# Altium schematic sheet GSM_EC200U.SchDoc(GSM_EC200U)
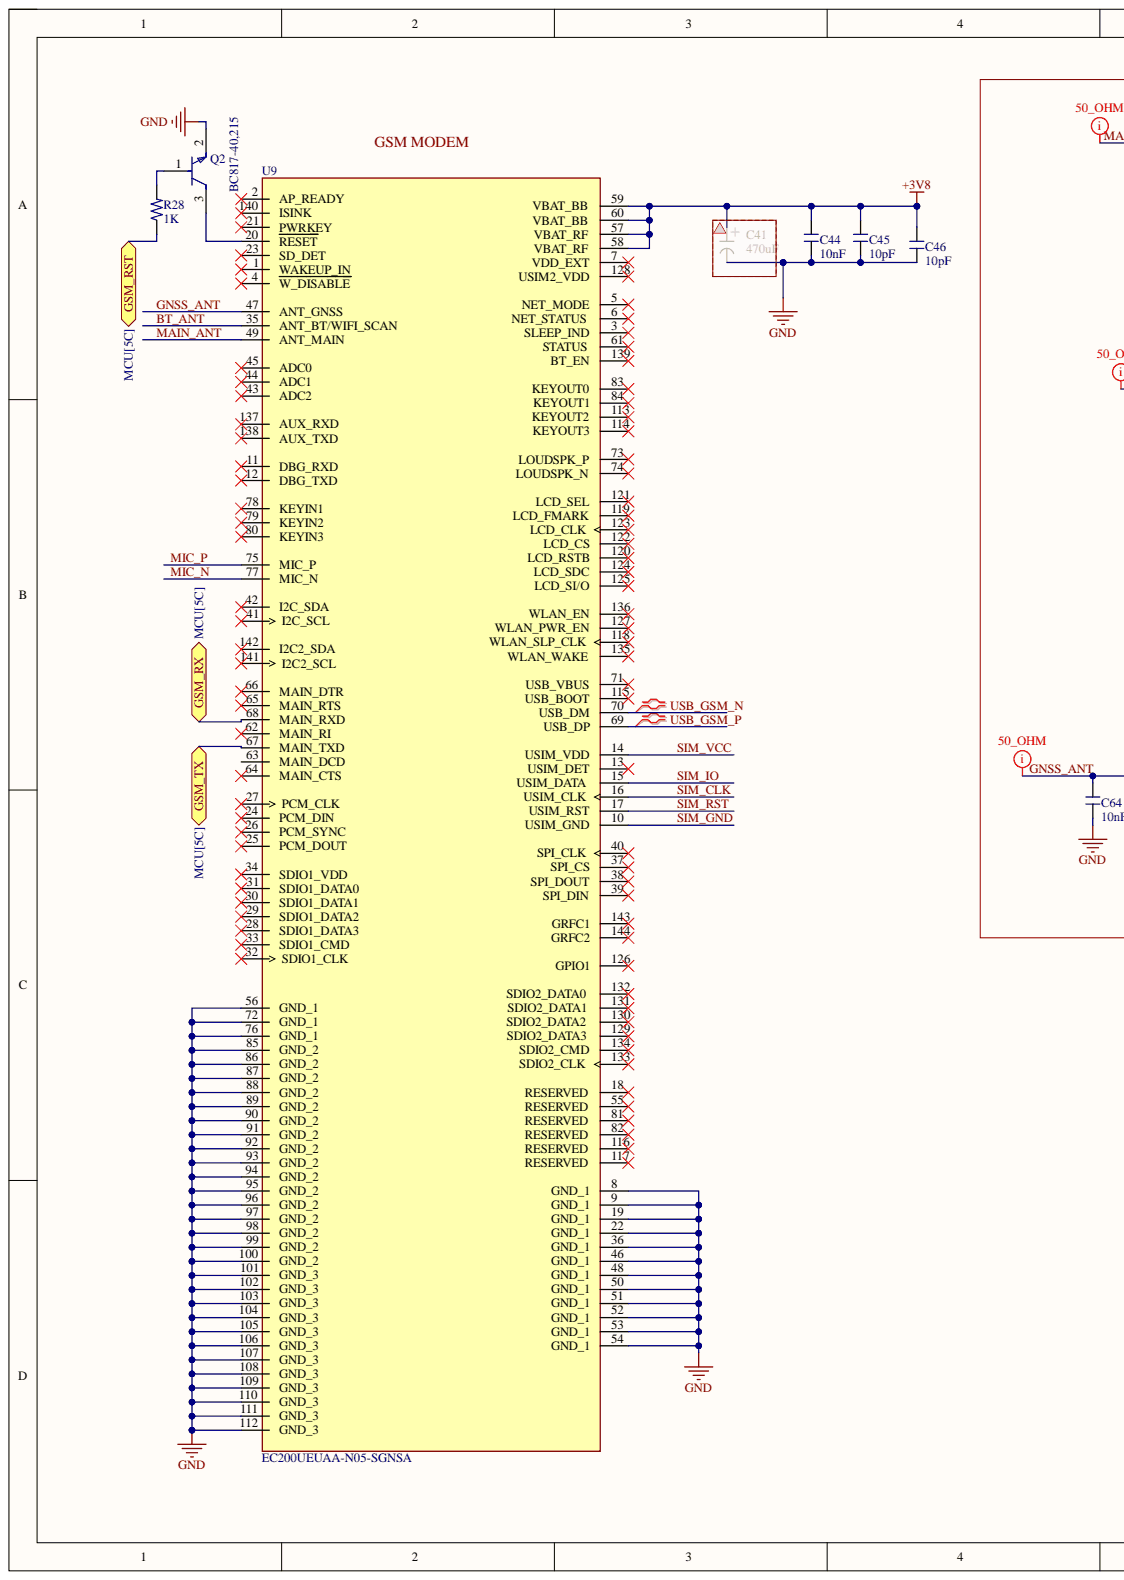
[diagram p0: GSM_EC200U.SchDoc, left]
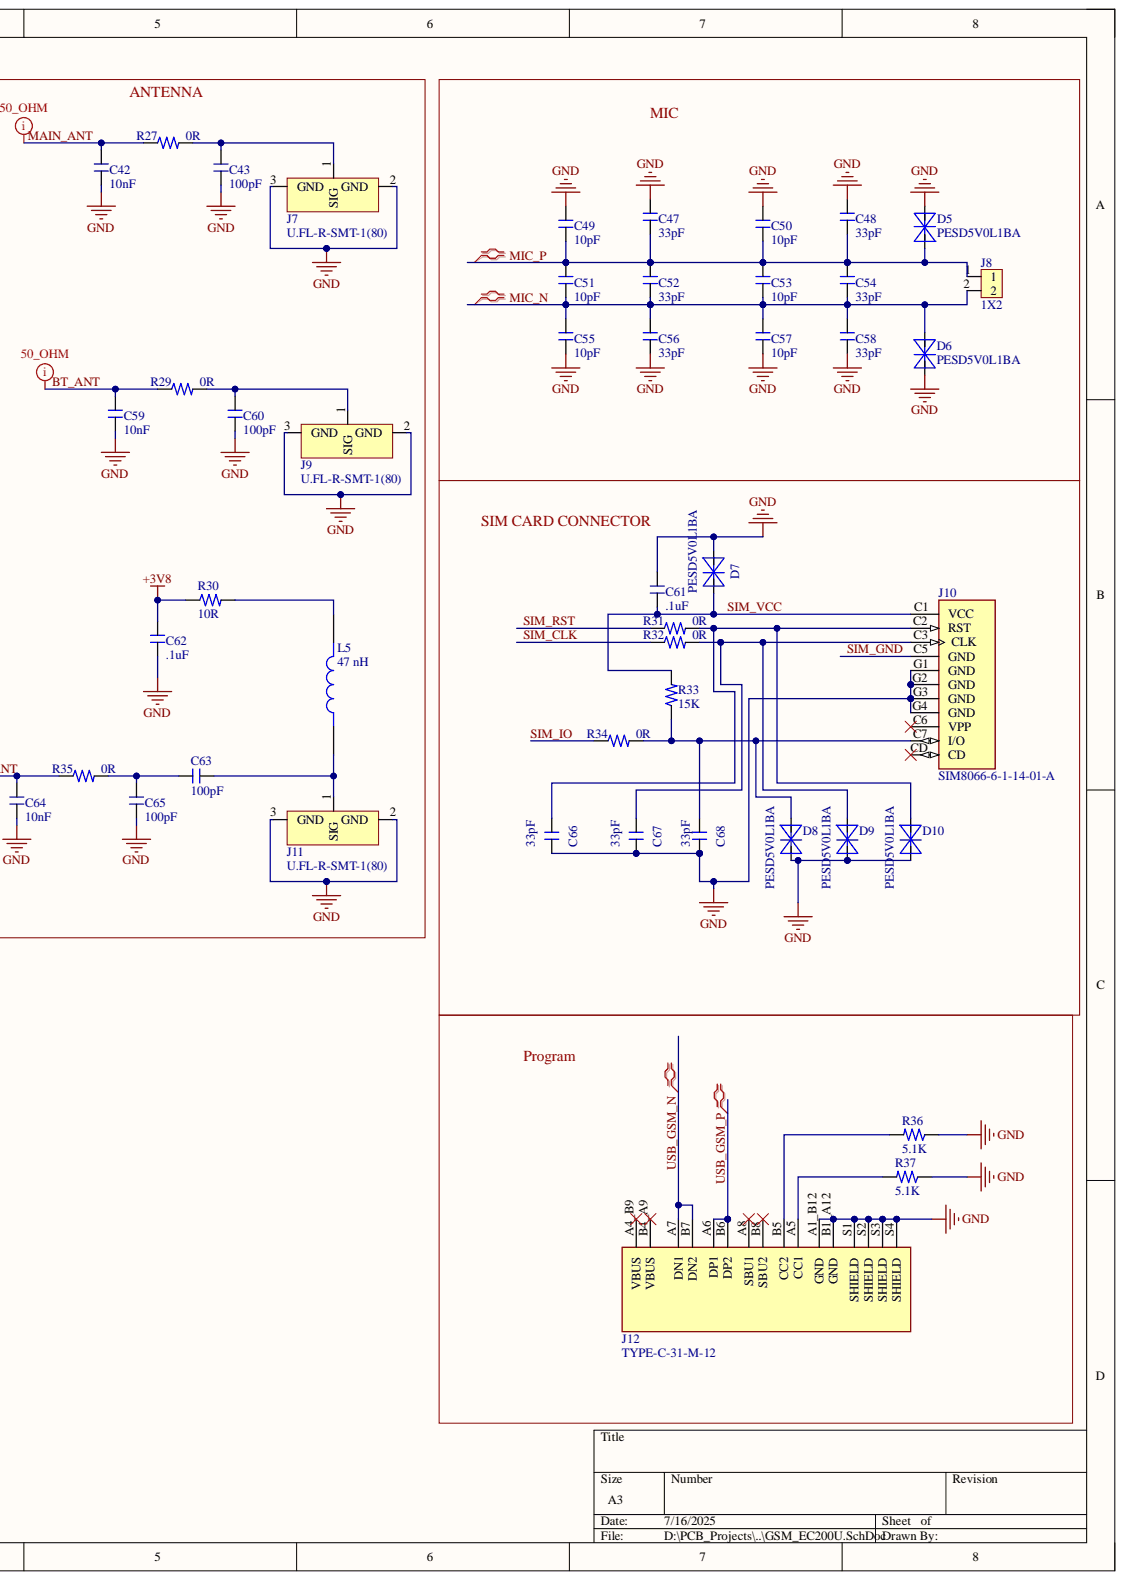
[diagram p1: GSM_EC200U.SchDoc, right]

## Parts
C42  10nF  [Capacitor_0805]
C43  100pF  [Capacitor_0805]
C44  10nF  [Capacitor_0805]
C45  10pF  [Capacitor_0805]
C46  10pF  [Capacitor_0805]
C47  33pF  [Capacitor_0805]
C48  33pF  [Capacitor_0805]
C49  10pF  [Capacitor_0805]
C50  10pF  [Capacitor_0805]
C51  10pF  [Capacitor_0805]
C52  33pF  [Capacitor_0805]
C53  10pF  [Capacitor_0805]
C54  33pF  [Capacitor_0805]
C55  10pF  [Capacitor_0805]
C56  33pF  [Capacitor_0805]
C57  10pF  [Capacitor_0805]
C58  33pF  [Capacitor_0805]
C59  10nF  [Capacitor_0805]
C60  100pF  [Capacitor_0805]
C61  .1uF  [FB_0805]
C62  .1uF  [FB_0805]
C63  100pF  [Capacitor_0805]
C64  10nF  [Capacitor_0805]
C65  100pF  [Capacitor_0805]
C66  33pF  [Capacitor_0805]
C67  33pF  [Capacitor_0805]
C68  33pF  [Capacitor_0805]
D5  PESD5V0L1BA  [SOT323]
D6  PESD5V0L1BA  [SOT323]
D7  PESD5V0L1BA  [SOT323]
D8  PESD5V0L1BA  [SOT323]
D9  PESD5V0L1BA  [SOT323]
D10  PESD5V0L1BA  [SOT323]
J7  U.FL-R-SMT-1(80)  [UFL]
J8  1X2  [Header 1x2 2.54mm]
J9  U.FL-R-SMT-1(80)  [UFL]
J10  SIM8066-6-1-14-01-A  [SIM]
J11  U.FL-R-SMT-1(80)  [UFL]
J12  TYPE-C-31-M-12  [TYPE-C-31-M-12]
L5  47 nH  [Resistor_0603]
Q2  BC817-40,215  [FP-SOT23-MFG]
R27  0R  [Resistor_0805]
R28  1K  [Resistor_0805]
R29  0R  [Resistor_0805]
R30  10R  [Resistor_0603]
R31  0R  [Resistor_0805]
R32  0R  [Resistor_0805]
R33  15K  [Resistor_0805]
R34  0R  [Resistor_0805]
R35  0R  [Resistor_0805]
R36  5.1K  [Resistor_0805]
R37  5.1K  [Resistor_0805]
U9  EC200UEUAA-N05-SGNSA  [EC200UEUAA-N05-SGNSA]

## Ports
GSM_RST, GSM_RX, GSM_TX

## Nets
+3V8: C44.2 C45.2 C46.2 C62.2 R30.1 U9.57(VBAT_RF) U9.58(VBAT_RF) U9.59(VBAT_BB) U9.60(VBAT_BB)
GND: C42.1 C43.2 C44.1 C45.1 C46.1 C47.2 C48.2 C49.2 C50.2 C55.1 C56.1 C57.1 C58.1 C59.1 C60.2 C61.2 C62.1 C64.1 C65.2 C66.1 C67.1 C68.1 D5.C D6.C D7.A D8.C D9.C D10.C J7.2 J7.3 J9.2 J9.3 J10.G1(GND) J10.G2(GND) J10.G3(GND) J10.G4(GND) J11.2 J11.3 J12.A1_B12(GND) J12.B1_A12(GND) J12.S1(SHIELD) J12.S2(SHIELD) J12.S3(SHIELD) J12.S4(SHIELD) Q2.2 R36.1 R37.1 U9.8(GND_1) U9.9(GND_1) U9.19(GND_1) U9.22(GND_1) U9.36(GND_1) U9.46(GND_1) U9.48(GND_1) U9.50(GND_1) U9.51(GND_1) U9.52(GND_1) U9.53(GND_1) U9.54(GND_1) U9.56(GND_1) U9.72(GND_1) U9.76(GND_1) U9.85(GND_2) U9.86(GND_2) U9.87(GND_2) U9.88(GND_2) U9.89(GND_2) U9.90(GND_2) U9.91(GND_2) U9.92(GND_2) U9.93(GND_2) U9.94(GND_2) U9.95(GND_2) U9.96(GND_2) U9.97(GND_2) U9.98(GND_2) U9.99(GND_2) U9.100(GND_2) U9.101(GND_3) U9.102(GND_3) U9.103(GND_3) U9.104(GND_3) U9.105(GND_3) U9.106(GND_3) U9.107(GND_3) U9.108(GND_3) U9.109(GND_3) U9.110(GND_3) U9.111(GND_3) U9.112(GND_3)
BT_ANT: C59.2 R29.1 U9.35(ANT_BT/WIFI_SCAN)
GNSS_ANT: C64.2 R35.1 U9.47(ANT_GNSS)
GSM_RST: R28.1 ; port GSM_RST
GSM_RX: U9.68(MAIN_RXD) ; port GSM_RX
GSM_TX: U9.67(MAIN_TXD) ; port GSM_TX
MAIN_ANT: C42.2 R27.1 U9.49(ANT_MAIN)
MIC_N: C51.1 C52.1 C53.1 C54.1 C55.2 C56.2 C57.2 C58.2 D6.A J8.2 U9.77(MIC_N)
MIC_P: C47.1 C48.1 C49.1 C50.1 C51.2 C52.2 C53.2 C54.2 D5.A J8.1 U9.75(MIC_P)
SIM_CLK: R32.1 U9.16(USIM_CLK)
SIM_GND: J10.C5(GND) U9.10(USIM_GND)
SIM_IO: R34.1 U9.15(USIM_DATA)
SIM_RST: R31.1 U9.17(USIM_RST)
SIM_VCC: C61.1 D7.C J10.C1(VCC) R33.2 U9.14(USIM_VDD)
USB_GSM_N: J12.A7(DN1) J12.B7(DN2) U9.70(USB_DM)
USB_GSM_P: J12.A6(DP1) J12.B6(DP2) U9.69(USB_DP)
NetC43_1: C43.1 J7.1 R27.2
NetC60_1: C60.1 J9.1 R29.2
NetC63_1: C63.1 C65.1 R35.2
NetC63_2: C63.2 J11.1 L5.1
NetC66_2: C66.2 D10.A J10.C2(RST) R31.2
NetC67_2: C67.2 D9.A J10.C3(CLK) R32.2
NetC68_2: C68.2 D8.A J10.C7(I/O) R33.1 R34.2
NetJ12_A5: J12.A5(CC1) R37.2
NetJ12_B5: J12.B5(CC2) R36.2
NetL5_2: L5.2 R30.2
NetQ2_1: Q2.1 R28.2
NetQ2_3: Q2.3 U9.20(RESET)

Not connected: J10 C6(VPP) CD; J12 A4_B9(VBUS) A8(SBU1) B4_A9(VBUS) B8(SBU2); U9 1(WAKEUP_IN) 2(AP_READY) 3(SLEEP_IND) 4(W_DISABLE) 5(NET_MODE) 6(NET_STATUS) 7(VDD_EXT) 11(DBG_RXD) 12(DBG_TXD) 13(USIM_DET) 18(RESERVED) 21(PWRKEY) 23(SD_DET) 24(PCM_DIN) 25(PCM_DOUT) 26(PCM_SYNC) 27(PCM_CLK) 28(SDIO1_DATA3) 29(SDIO1_DATA2) 30(SDIO1_DATA1) 31(SDIO1_DATA0) 32(SDIO1_CLK) 33(SDIO1_CMD) 34(SDIO1_VDD) 37(SPI_CS) 38(SPI_DOUT) 39(SPI_DIN) 40(SPI_CLK) 41(I2C_SCL) 42(I2C_SDA) 43(ADC2) 44(ADC1) 45(ADC0) 55(RESERVED) 61(STATUS) 62(MAIN_RI) 63(MAIN_DCD) 64(MAIN_CTS) 65(MAIN_RTS) 66(MAIN_DTR) 71(USB_VBUS) 73(LOUDSPK_P) 74(LOUDSPK_N) 78(KEYIN1) 79(KEYIN2) 80(KEYIN3) 81(RESERVED) 82(RESERVED) 83(KEYOUT0) 84(KEYOUT1) 113(KEYOUT2) 114(KEYOUT3) 115(USB_BOOT) 116(RESERVED) 117(RESERVED) 118(WLAN_SLP_CLK) 119(LCD_FMARK) 120(LCD_RSTB) 121(LCD_SEL) 122(LCD_CS) 123(LCD_CLK) 124(LCD_SDC) 125(LCD_SI/O) 126(GPIO1) 127(WLAN_PWR_EN) 128(USIM2_VDD) 129(SDIO2_DATA3) 130(SDIO2_DATA2) 131(SDIO2_DATA1) 132(SDIO2_DATA0) 133(SDIO2_CLK) 134(SDIO2_CMD) 135(WLAN_WAKE) 136(WLAN_EN) 137(AUX_RXD) 138(AUX_TXD) 139(BT_EN) 140(ISINK) 141(I2C2_SCL) 142(I2C2_SDA) 143(GRFC1) 144(GRFC2)

## Library data of the parts
C42 C44 C59 C64 = Cap_10nF 0805: Multilayer Ceramic Capacitor, 0.01 uF, 50 V, ï¿½ 10%, X7R, 0805 [2012 Metric]; Manufacturer: Samsung; Manufacturer Part Number: CL21B103KBANNNC; Voltage: 50V; Case Code (Imperial): 0805; Case Code (Metric): 2012; Case/Package: 0805; Category: Ceramic Capacitors; Component Kind: Standard; ComponentLink1Description: https://datasheet.ciiva.com/25709/cl21b103kbannnc-25709781.pdf?src-supplier=Digikey; ComponentLink1URL: https://datasheet.ciiva.com/25709/cl21b103kbannnc-25709781.pdf?src-supplier=Digikey; ComponentLink2Description: https://datasheet.ciiva.com/29199/455082-da-01-en-vs-kondensator-0805-29199459.pdf?src-supplier=Conrad; ComponentLink2URL: https://datasheet.ciiva.com/29199/455082-da-01-en-vs-kondensator-0805-29199459.pdf?src-supplier=Conrad; Construction: Flat; Depth: 1.25mm; Dielectric: X7R; Height: 0.65mm; Lead Free: Lead Free; Length: 2mm; Library Name: Schlib1.SchLib; Material: Ceramic; Max Operating Temperature: 125°C; Min Operating Temperature: -55°C; Mounting Technology: Surface Mount; Packaging: Tape and Reel; Pins: 2; Pricing: 1=0,08, 10=0,011, 100=0,0096, 1000=0,0072 (USD); Radiation Hardening: No; RoHS: Yes; RoHS Compliant: Yes; Series: CL; Stock: 2729; Supplier: Digikey; Supplier Part Number: 1276-1015-1-ND; Tolerance: 10%; Value: 10nF; Voltage Rating: 50V; Voltage Rating (DC): 50V
C43 C60 C63 C65 = CAP 100pF 0805: 0805 100 pF 50 V ±5 % Tolerance NP0 SMT Multilayer Ceramic Capacitor; Manufacturer: Yageo; Manufacturer Part Number: CC0805JRNPO9BN101; Dielectric: C0G; Insulation Resistance: 100GR; Material: 4241ConductorMaterial; Temperature Coefficient: 30ppm/°C; Tolerance: 5%; Voltage Rating: 50V; Case Code (Imperial): 0805; Case Code (Metric): 2012; Case/Package: 0805; Category: Ceramic Capacitors; Component Kind: Standard; ComponentLink1Description: https://datasheet.ciiva.com/5425/upy-gp-np0-16v-to-50v-8-217813-5425504.pdf?src-supplier=Mouser; ComponentLink1URL: https://datasheet.ciiva.com/5425/upy-gp-np0-16v-to-50v-8-217813-5425504.pdf?src-supplier=Mouser; ComponentLink2Description: https://datasheet.ciiva.com/144/mlccorderingcode-144855.pdf?src-supplier=Digikey; ComponentLink2URL: https://datasheet.ciiva.com/144/mlccorderingcode-144855.pdf?src-supplier=Digikey; ComponentLink3Description: https://datasheet.ciiva.com/144/mlccorderingcode-144854.pdf?src-supplier=Digikey; ComponentLink3URL: https://datasheet.ciiva.com/144/mlccorderingcode-144854.pdf?src-supplier=Digikey; ComponentLink4Description: https://datasheet.ciiva.com/144/mlccorderingcode-144856.pdf?src-supplier=Digikey; ComponentLink4URL: https://datasheet.ciiva.com/144/mlccorderingcode-144856.pdf?src-supplier=Digikey; ComponentLink5Description: https://datasheet.ciiva.com/8033/1443161-8033950.pdf?src-supplier=Element14; ComponentLink5URL: https://datasheet.ciiva.com/8033/1443161-8033950.pdf?src-supplier=Element14; ComponentLink6Description: https://datasheet.ciiva.com/11464/upy-gp-np0-16v-to-50v-8-11464745.pdf?src-supplier=Avnet; ComponentLink6URL: https://datasheet.ciiva.com/11464/upy-gp-np0-16v-to-50v-8-11464745.pdf?src-supplier=Avnet; ComponentLink7Description: https://datasheet.ciiva.com/8033/1443161-8033947.pdf?src-supplier=Element14; ComponentLink7URL: https://datasheet.ciiva.com/8033/1443161-8033947.pdf?src-supplier=Element14; ComponentLink8Description: https://datasheet.ciiva.com/26829/getdatasheetpartid-92514-26829509.pdf?src-supplier=Verical; ComponentLink8URL: https://datasheet.ciiva.com/26829/getdatasheetpartid-92514-26829509.pdf?src-supplier=Verical; Depth: 1.25mm; Dielectric Material: Ceramic; Halogen Free: 5694HalogenFree; Height: 0.6mm; Lead Free: Lead Free; Length: 2mm; Library Name: Schlib1.SchLib; Max Operating Temperature: 125°C; Min Operating Temperature: -55°C; Mounting Technology: Surface Mount; Package Quantity: 4000; Packaging: Tape and Reel; Pins: 2; Pricing: 4000=0,0128, 8000=0,0088, 12000=0,0087, 28000=0,0086, 40000=0,0073, 100000=0,0072, 200000=0,005 (USD); Radiation Hardening: No; Reach SVHC Compliant: No; RoHS: Yes; RoHS Compliant: Yes; Series: CC; Stock: 6144000; Supplier: Arrow Electronics; Supplier Part Number: CC0805JRNPO9BN101; Termination: SMD/SMT; Value: 100pF; Voltage Rating (AC): 50V; Voltage Rating (DC): 50V; Width: 1.25mm
C45 C46 C49-C51 C53 C55 C57 = CAP 10pF 0805: Multilayer Ceramic Capacitor, 10 pF, 50 V, ï¿½ 5%, C0G (NP0), 0805 [2012 Metric]; Manufacturer: Yageo; Manufacturer Part Number: CC0805JRNPO9BN100; Temperature Coefficient: 30ppm/°C; Category: Ceramic Capacitors; Component Kind: Standard; ComponentLink1Description: https://datasheet.ciiva.com/144/mlccorderingcode-144670.pdf?src-supplier=Digikey; ComponentLink1URL: https://datasheet.ciiva.com/144/mlccorderingcode-144670.pdf?src-supplier=Digikey; ComponentLink2Description: https://datasheet.ciiva.com/144/mlccorderingcode-144671.pdf?src-supplier=Digikey; ComponentLink2URL: https://datasheet.ciiva.com/144/mlccorderingcode-144671.pdf?src-supplier=Digikey; ComponentLink3Description: https://datasheet.ciiva.com/144/mlccorderingcode-144672.pdf?src-supplier=Digikey; ComponentLink3URL: https://datasheet.ciiva.com/144/mlccorderingcode-144672.pdf?src-supplier=Digikey; ComponentLink4Description: https://datasheet.ciiva.com/11464/upy-gp-np0-16v-to-50v-8-11464744.pdf?src-supplier=Avnet; ComponentLink4URL: https://datasheet.ciiva.com/11464/upy-gp-np0-16v-to-50v-8-11464744.pdf?src-supplier=Avnet; Halogen Free: 5694HalogenFree; Lead Free: 5697LeadFree; Library Name: Schlib1.SchLib; Pins: 2NUMBER; Pricing: 1=0,1, 10=0,048, 100=0,0284, 1000=0,01828 (USD); RoHS: Yes; Stock: 29904; Supplier: Digikey; Supplier Part Number: 311-1099-1-ND
C47 C48 C52 C54 C56 C58 C66-C68 = CAP 33pF: Multilayer Ceramic Capacitor, 33 pF, 50 V, ï¿½ 5, C0G (NP0), 0805 [2012 Metric]; Manufacturer: Yageo; Manufacturer Part Number: CC0805JRNPO9BN330; Dielectric Material: 4241ConductorMaterial; Temperature Coefficient: 30ppm/°C; Voltage Rating (AC): 50V; Category: Ceramic Capacitors; Component Kind: Standard; ComponentLink1Description: https://datasheet.ciiva.com/8033/1443161-8033942.pdf?src-supplier=Element14; ComponentLink1URL: https://datasheet.ciiva.com/8033/1443161-8033942.pdf?src-supplier=Element14; ComponentLink2Description: https://datasheet.ciiva.com/8033/1443161-8033944.pdf?src-supplier=Element14; ComponentLink2URL: https://datasheet.ciiva.com/8033/1443161-8033944.pdf?src-supplier=Element14; ComponentLink3Description: https://datasheet.ciiva.com/144/mlccorderingcode-144778.pdf?src-supplier=Digikey; ComponentLink3URL: https://datasheet.ciiva.com/144/mlccorderingcode-144778.pdf?src-supplier=Digikey; ComponentLink4Description: https://datasheet.ciiva.com/144/mlccorderingcode-144779.pdf?src-supplier=Digikey; ComponentLink4URL: https://datasheet.ciiva.com/144/mlccorderingcode-144779.pdf?src-supplier=Digikey; ComponentLink5Description: https://datasheet.ciiva.com/144/mlccorderingcode-144780.pdf?src-supplier=Digikey; ComponentLink5URL: https://datasheet.ciiva.com/144/mlccorderingcode-144780.pdf?src-supplier=Digikey; ComponentLink6Description: https://datasheet.ciiva.com/11464/upy-gp-np0-16v-to-50v-8-11464747.pdf?src-supplier=Avnet; ComponentLink6URL: https://datasheet.ciiva.com/11464/upy-gp-np0-16v-to-50v-8-11464747.pdf?src-supplier=Avnet; ComponentLink7Description: https://datasheet.ciiva.com/29241/445349-da-01-en-kerko-chip-0805-np0-33pf-5proz-50v-g-29241975.pdf?src-supplier=Conrad; ComponentLink7URL: https://datasheet.ciiva.com/29241/445349-da-01-en-kerko-chip-0805-np0-33pf-5proz-50v-g-29241975.pdf?src-supplier=Conrad; Halogen Free: 5694HalogenFree; Lead Free: 5697LeadFree; Library Name: Schlib1.SchLib; Pricing: 4000=0,0099, 8000=0,0078, 12000=0,0077, 20000=0,005 (USD); RoHS: Yes; Stock: 532000; Supplier: Arrow Electronics; Supplier Part Number: CC0805JRNPO9BN330
C61 C62 = CAP .1 UF: Cap Ceramic 0.1uF 100V X7R 10% Pad SMD 0805 Fail Safe with Soft Termination 125C Automotive T/R; Manufacturer: Murata; Manufacturer Part Number: GCE21BR72A104KA01L; Material: 4241ConductorMaterial; Value: 100nF; Case Code (Imperial): 0805; Case Code (Metric): 2012; Case/Package: 0805; Category: Ceramic Capacitors; Component Kind: Standard; ComponentLink1Description: http://search.murata.co.jp/Ceramy/image/img/A01X/partnumbering_e_02.pdf?src-supplier=Digikey; ComponentLink1URL: http://search.murata.co.jp/Ceramy/image/img/A01X/partnumbering_e_02.pdf?src-supplier=Digikey; ComponentLink2Description: http://search.murata.co.jp/Ceramy/image/img/A01X/G101/ENG/GCE21BR72A104KA01-01.pdf?src-supplier=Digikey; ComponentLink2URL: http://search.murata.co.jp/Ceramy/image/img/A01X/G101/ENG/GCE21BR72A104KA01-01.pdf?src-supplier=Digikey; Features: Soft Termination; Height: 1.45mm; Lead Free: Lead Free; Length: 2mm; Library Name: Schlib1.SchLib; Max Operating Temperature: 125°C; Min Operating Temperature: -55°C; Mounting Technology: Surface Mount; Packaging: Cut Tape; Pricing: 1=0,25, 10=0,152, 50=0,152, 100=0,1, 1000=0,073, 10000=0,055 (USD); Ratings: AEC-Q200; RoHS: Yes; RoHS Compliant: Yes; Series: GCE; Stock: 45477; Supplier: Mouser; Supplier Part Number: 81-GCE21BR72A104KA1L; Thickness: 1.25mm; Tolerance: 10%; Voltage Rating: 100V; Width: 1.25mm
D5-D10 = ESD PESD5V0L1BA: Esd Protection Device, Tvs, 7.6 V, Sod-323, 2 Rohs Compliant: Yes; Manufacturer: NXP Semiconductors; Breakdown Voltage: 7V; Number of Channels: 1NUMBER; Operating Supply Voltage: 5V; Category: TVS Diodes; Component Kind: Standard; ComponentLink1Description: https://datasheet.ciiva.com/7973/682594-7973878.pdf?src-supplier=Element14; ComponentLink1URL: https://datasheet.ciiva.com/7973/682594-7973878.pdf?src-supplier=Element14; Library Name: Schlib1.SchLib; Manufacturer Part Number: PESD5V0L1BA; Pricing: 20=0,211, 200=0,195, 500=0,183, 1000=0,167, 2000=0,154 (EUR); RoHS: Yes; Supplier: Rs; Supplier Part Number: 0509447
J7 J9 J11 = U.FL-R-SMT-1(80): RF COAX Connector, U.FL JACK, PCB, 50 OHM; Co; Connector Type: U.FL Coaxial; Body Style: Straight; Manufacturer: Hirose; Frequency: 8GHz; Number of Contacts: 1NUMBER; Category: RF / Coaxial Connectors; Component Kind: Standard; ComponentLink1Description: http://www.mouser.com/ds/2/185/U.FL_catalog-939761.pdf?src-supplier=Mouser; ComponentLink1URL: http://www.mouser.com/ds/2/185/U.FL_catalog-939761.pdf?src-supplier=Mouser; ComponentLink2Description: https://www.hirose.com/product/en/download_file/key_name/U.FL-R-SMT-1%2880%29/category/Specification%20Sheet/doc_file_id/37638/?file_category_id=9&item_id=03310472280&is_series=&src-supplier=Digikey; ComponentLink2URL: https://www.hirose.com/product/en/download_file/key_name/U.FL-R-SMT-1%2880%29/category/Specification%20Sheet/doc_file_id/37638/?file_category_id=9&item_id=03310472280&is_series=&src-supplier=Digikey; ComponentLink3Description: https://datasheet.octopart.com/U.FL-R-SMT-1%2880%29-Hirose-datasheet-137256329.pdf?src-supplier=Future+Electronics; ComponentLink3URL: https://datasheet.octopart.com/U.FL-R-SMT-1%2880%29-Hirose-datasheet-137256329.pdf?src-supplier=Future+Electronics; ComponentLink4Description: https://datasheet.octopart.com/U.FL-R-SMT-1%2880%29-Hirose-datasheet-151510633.pdf?src-supplier=TME; ComponentLink4URL: https://datasheet.octopart.com/U.FL-R-SMT-1%2880%29-Hirose-datasheet-151510633.pdf?src-supplier=TME; Contact Plating: 157,159ConductorMaterial; Height: 1.45mm; Lead Free: 5697LeadFree; Library Name: Schlib1.SchLib; Manufacturer Part Number: U.FL-R-SMT-1(80); Orientation: 5491OrientationType; Pricing: 10000=0,62403 (USD); RoHS: Yes; Stock: 10; Supplier: Avnet; Supplier Part Number: U.FL-R-SMT-1(80)
J8 = Header 1X2 2.54 mm: Conn Unshrouded Header HDR 2 POS 2.54mm Solder ST Thru-Hole Automotive Carton; Manufacturer: TE Connectivity; Manufacturer Part Number: 826629-2; Contact Style: 4753ConnectorType; Current Rating: 5A; Gender: 29Gender; Insulation Resistance: 5GR; Number of Contacts: 2NUMBER; Category: Headers and Wire Housings; Color: 49Colour; Component Kind: Standard; ComponentLink1Description: https://www.te.com/commerce/DocumentDelivery/DDEController?Action=srchrtrv&amp;DocNm=826629&amp;DocType=Customer+Drawing&amp;DocLang=English&src-supplier=Digikey; ComponentLink1URL: https://www.te.com/commerce/DocumentDelivery/DDEController?Action=srchrtrv&amp;DocNm=826629&amp;DocType=Customer+Drawing&amp;DocLang=English&src-supplier=Digikey; ComponentLink2Description: https://datasheet.octopart.com/826629-2-TE-Connectivity---AMP-datasheet-17024315.pdf?src-supplier=Element14; ComponentLink2URL: https://datasheet.octopart.com/826629-2-TE-Connectivity---AMP-datasheet-17024315.pdf?src-supplier=Element14; ComponentLink3Description: https://datasheet.octopart.com/826629-2-TE-Connectivity---AMP-datasheet-179310416.pdf?src-supplier=Farnell; ComponentLink3URL: https://datasheet.octopart.com/826629-2-TE-Connectivity---AMP-datasheet-179310416.pdf?src-supplier=Farnell; ComponentLink4Description: https://datasheet.octopart.com/826629-2-TE-Connectivity---AMP-datasheet-44414285.pdf?src-supplier=Electro+Sonic; ComponentLink4URL: https://datasheet.octopart.com/826629-2-TE-Connectivity---AMP-datasheet-44414285.pdf?src-supplier=Electro+Sonic; ComponentLink5Description: https://datasheet.octopart.com/826629-2-TE-Connectivity-datasheet-181566106.pdf?src-supplier=Sager+Electronics; ComponentLink5URL: https://datasheet.octopart.com/826629-2-TE-Connectivity-datasheet-181566106.pdf?src-supplier=Sager+Electronics; Contact Plating: 154,157ConductorMaterial; Height: 10mm; Housing Color: 49Colour; Housing Material: 175ConductorMaterial; Lead Free: 5697LeadFree; Lead Pitch: 2.54mm; Library Name: Schlib1.SchLib; Orientation: 5495OrientationType; Pins: 2NUMBER; Pricing: 1=0,15044, 10=0,14915, 30=0,14703, 50=0,14491, 100=0,14279 (USD); RoHS: Yes; RoHS Compliant: 5897YesNo; Row Spacing: 2.54mm; Stock: 21749; Supplier: Avnet; Supplier Part Number: 826629-2
J10 = SIM8066-6-1-14-01-A: SIM8066 Series 6 Position 1.44 mm Pitch Push Push Type Nano SIM Card Connector; Manufacturer: Global Connector Technology; Category: Connectors; Component Kind: Standard; ComponentLink1Description: https://datasheet.octopart.com/SIM8066-6-1-14-01-A-Global-Connector-Technology-datasheet-155811920.pdf?src-supplier=Future+Electronics; ComponentLink1URL: https://datasheet.octopart.com/SIM8066-6-1-14-01-A-Global-Connector-Technology-datasheet-155811920.pdf?src-supplier=Future+Electronics; Library Name: Schlib1.SchLib; Manufacturer Part Number: SIM8066-6-1-14-01-A; Pins: 6; Pricing: 1=1,11, 37=0,904, 112=0,859, 300=0,813, 750=0,677 (EUR); RoHS: No; Stock: 12609; Supplier: Farnell; Supplier Part Number: 3772945
J12 = TYPE-C-31-M-12: Manufacturer: HRO Electronics; Category: LDO; Component Kind: Standard; Library Name: Schlib1.SchLib; Manufacturer Part Number: TYPE-C-31-M-12; Pricing: 5=0,171, 50=0,1346, 150=0,1168, 1000=0,0966, 2000=0,0923, 5000=0,0896 (USD); RoHS: No; Stock: 137245; Supplier: LCSC; Supplier Part Number: C165948
L5 = Inductor 47 nH 0603: Ind RF Chip Shielded Multi-Layer 47nH 20% 50MHz 10Q-Factor Ferrite 200mA 0603 Punched Paper T/R; Manufacturer: TDK EPCOS; Manufacturer Part Number: MLF1608D47NMTA00; Category: Fixed Inductors; Component Kind: Standard; ComponentLink1Description: https://datasheet.ciiva.com/29698/inductor-commercial-standard-mlf-en-29698906.pdf?src-supplier=Digikey; ComponentLink1URL: https://datasheet.ciiva.com/29698/inductor-commercial-standard-mlf-en-29698906.pdf?src-supplier=Digikey; ComponentLink2Description: https://datasheet.ciiva.com/29698/inductor-commercial-standard-mlf-en-29698907.pdf?src-supplier=Digikey; ComponentLink2URL: https://datasheet.ciiva.com/29698/inductor-commercial-standard-mlf-en-29698907.pdf?src-supplier=Digikey; ComponentLink3Description: https://datasheet.ciiva.com/29698/inductor-commercial-standard-mlf-en-29698908.pdf?src-supplier=Digikey; ComponentLink3URL: https://datasheet.ciiva.com/29698/inductor-commercial-standard-mlf-en-29698908.pdf?src-supplier=Digikey; Library Name: Schlib1.SchLib; Pricing: 1=0,1, 10=0,084, 50=0,084, 100=0,069, 1000=0,057, 10000=0,044 (USD); RoHS: Yes; Stock: 24480; Supplier: Mouser; Supplier Part Number: 810-MLF1608D47NMTA00
Q2 = CMP-14406-000007-1: TRANS NPN 45V 0.5A SOT23; Manufacturer: Nexperia; Automotive Grade: Grade 0; Collector Base Voltage: 50V; Collector Emitter Saturation Voltage: 700mV; Emitter Base Voltage: 5V; Hfe Max: 600; Hfe Min: 250; Hfe Testic: 100mA; Hfe Testvce: 1V; Max Collector Current: 500mA; Max Junction Temp: +150°C; Number Of Elements: 1; Power Dissipation: 250mW; Rthja Max: 500K/W; Unity Gain Freq: 100MHz; Ambient Temperature Range High: +150°C; Ambient Temperature Range Low: -65°C; Automotive: Yes; Category: Trans; Collector Emitter Breakdown Voltage: 45V; Collector Emitter Voltage: 45V; Component Kind: Standard; ComponentLink2Description: Datasheet; ComponentLink2URL: https://assets.nexperia.com/documents/data-sheet/BC817_SER.pdf; Device Class L1: Discrete Semiconductors; Device Class L2: Transistors; Device Class L3: BJTs; Digikey Description: TRANS NPN 45V 0.5A SOT23; Footprint Url: https://assets.nexperia.com/documents/outline-drawing/SOT23.pdf; Height: 1.1mm; Ipc Land Pattern Name: SOT95P230X100-3; Lead Free: Yes; Library Name: Altium Content Vault; Manufacturer Part Number: BC817-40,215; Mouser Description: BC817 Series 45 V 500 mA 250 mW SMT NPN General-Purpose Transistor - SOT-23-; Number Of Npn: 1; Octopart: https://octopart.com/bc817-40%2C215-nexperia-78739081; Package: SOT23; RoHS: TRUE; Temperature: to +150°C; Temperature Range High: +150°C
R27 R29 R31 R32 R34 R35 = Res 0 R 0805: GENERAL PURPOSE CHIP RESISTOR Metal Glaze/thick Film, 0.125W, 0ohm, Surface Mount, 0805; Manufacturer: Yageo; Manufacturer Part Number: RC0805JR-070RL; Max Power Dissipation: 125mW; Resistance Tolerance: 5%; Temperature Coefficient: 200ppm/°C; Value: 0mR; Voltage Rating: 150V; Case Code (Imperial): 0805; Case Code (Metric): 2012; Case/Package: 0805; Category: Chip SMD Resistors; Component Kind: Standard; ComponentLink1Description: https://datasheet.ciiva.com/11819/0900766b8106beab-11819430.pdf?src-supplier=Rs; ComponentLink1URL: https://datasheet.ciiva.com/11819/0900766b8106beab-11819430.pdf?src-supplier=Rs; ComponentLink2Description: https://datasheet.ciiva.com/7941/1738694-7941861.pdf?src-supplier=Element14; ComponentLink2URL: https://datasheet.ciiva.com/7941/1738694-7941861.pdf?src-supplier=Element14; ComponentLink3Description: https://datasheet.ciiva.com/7941/1738694-7941862.pdf?src-supplier=Element14; ComponentLink3URL: https://datasheet.ciiva.com/7941/1738694-7941862.pdf?src-supplier=Element14; ComponentLink4Description: https://datasheet.ciiva.com/7941/1738694-7941863.pdf?src-supplier=Element14; ComponentLink4URL: https://datasheet.ciiva.com/7941/1738694-7941863.pdf?src-supplier=Element14; Construction: Rectangular; Contact Plating: Tin,Matte; Depth: 1.25mm; Features: Moisture Resistant; Height: 0.5mm; Lead Free: 5697LeadFree; Length: 2mm; Library Name: Schlib1.SchLib; Max Operating Temperature: 155°C; Military Standard: Not; Min Operating Temperature: -55°C; Number of Terminations: 2; Packaging: Tape and Reel; Pins: 2; Power Rating: 125mW; Pricing: 1=0,1, 10=0,015, 25=0,0128, 50=0,011, 100=0,0097, 250=0,00812, 500=0,00716, 1000=0,00633 (USD); Reach SVHC Compliant: Unknown; Resistor Type: Thick Film; RoHS: Yes; RoHS Compliant: Yes; Series: RC; Stock: 947133; Supplier: Digikey; Supplier Part Number: 311-0.0ARCT-ND; Technology: THICK FILM; Termination: SMD/SMT; Tolerance: 5%; Voltage Rating (DC): 150V; Width: 1.25mm
R28 = Res 1K 0805: 125Mw Thick Film Resistors 100Ppm/ 1% 1K 0805 Chip Resistor Surface Mount Rohs; Manufacturer: Yageo; Manufacturer Part Number: RC0805FR-071KL; Temperature Coefficient: 100ppm/°C; Value: 1kR; Voltage Rating: 150V; Case Code (Imperial): 0805; Case Code (Metric): 2012; Case/Package: 0805; Category: Chip SMD Resistors; Component Kind: Standard; ComponentLink1Description: https://datasheet.ciiva.com/7941/1686128-7941719.pdf?src-supplier=Element14; ComponentLink1URL: https://datasheet.ciiva.com/7941/1686128-7941719.pdf?src-supplier=Element14; ComponentLink2Description: https://datasheet.ciiva.com/46385/444164-46385020.pdf?src-supplier=Element14; ComponentLink2URL: https://datasheet.ciiva.com/46385/444164-46385020.pdf?src-supplier=Element14; ComponentLink3Description: https://datasheet.ciiva.com/2456/pyu-r-int-thick-7-2456976.pdf?src-supplier=Digikey; ComponentLink3URL: https://datasheet.ciiva.com/2456/pyu-r-int-thick-7-2456976.pdf?src-supplier=Digikey; ComponentLink4Description: https://datasheet.ciiva.com/2456/pyu-r-int-thick-7-2456977.pdf?src-supplier=Digikey; ComponentLink4URL: https://datasheet.ciiva.com/2456/pyu-r-int-thick-7-2456977.pdf?src-supplier=Digikey; ComponentLink5Description: https://datasheet.ciiva.com/2456/pyu-r-int-thick-7-2456975.pdf?src-supplier=Digikey; ComponentLink5URL: https://datasheet.ciiva.com/2456/pyu-r-int-thick-7-2456975.pdf?src-supplier=Digikey; ComponentLink6Description: https://datasheet.ciiva.com/16850/rc0805-16850409.pdf?src-supplier=Future+Electronics; ComponentLink6URL: https://datasheet.ciiva.com/16850/rc0805-16850409.pdf?src-supplier=Future+Electronics; Construction: Rectangular; Depth: 1.25mm; Features: Moisture Resistant; Height: 0.024inch; Lead Free: Lead Free; Length: 0.079inch; Library Name: Schlib1.SchLib; Max Operating Temperature: 155°C; Max Power Dissipation: 125mW; Military Standard: Not; Min Operating Temperature: -55°C; Number of Terminations: 2; Package Quantity: 1; Packaging: Tape and Reel; Pins: 2; Power Rating: 125mW; Pricing: 10=0,014, 25=0,012, 50=0,01, 100=0,009 (USD); Reach SVHC Compliant: No; Resistance Tolerance: 1%; Resistor Type: Thick Film; RoHS: Yes; RoHS Compliant: Yes; Series: RC; Stock: 255910; Supplier: Avnet; Supplier Part Number: 82K7536; Termination: SMD/SMT; Tolerance: 1%; Voltage Rating (AC): 150V; Voltage Rating (DC): 150V; Width: 0.049inch
R30 = Res 10 OHM 0603: Res Thick Film 0603 10 Ohm 1% 0.1W(1/10W) ±200ppm/C Pad SMD T/R; Manufacturer: Yageo; Manufacturer Part Number: RC0603FR-0710RL; Max Power Dissipation: 100mW; Temperature Coefficient: 200ppm/°C; Voltage Rating: 50V; Case Code (Imperial): 0603; Case Code (Metric): 1608; Case/Package: 0603; Category: Chip SMD Resistors; Component Kind: Standard; ComponentLink1Description: https://datasheet.ciiva.com/11808/0900766b80f96399-11808140.pdf?src-supplier=Rs; ComponentLink1URL: https://datasheet.ciiva.com/11808/0900766b80f96399-11808140.pdf?src-supplier=Rs; ComponentLink2Description: https://datasheet.ciiva.com/7746/443971-7746245.pdf?src-supplier=Element14; ComponentLink2URL: https://datasheet.ciiva.com/7746/443971-7746245.pdf?src-supplier=Element14; ComponentLink3Description: https://datasheet.ciiva.com/8891/pyu-rc0603-51-rohs-l-4-8891285.pdf?src-supplier=Avnet; ComponentLink3URL: https://datasheet.ciiva.com/8891/pyu-rc0603-51-rohs-l-4-8891285.pdf?src-supplier=Avnet; Construction: Rectangular; Contact Plating: Tin,Matte; Depth: 0.8mm; Features: Moisture Resistant; Height: 0.45mm; Lead Free: 5697LeadFree; Length: 1.6mm; Library Name: Schlib1.SchLib; Max Operating Temperature: 155°C; Military Standard: Not; Min Operating Temperature: -55°C; Number of Terminations: 2; Packaging: Tape and Reel; Pins: 2; Power Rating: 100mW; Pricing: 5000=0,00309, 10000=0,00304, 20000=0,003, 30000=0,00295, 40000=0,0029 (USD); Reach SVHC Compliant: No; Resistance Tolerance: 1%; Resistor Type: Thick Film; RoHS: Yes; RoHS Compliant: Yes; Series: RC; Stock: 1840000; Supplier: Avnet; Supplier Part Number: RC0603FR-0710RL; Technology: THICK FILM; Termination: SMD/SMT; Tolerance: 1%; Value: 10R; Voltage Rating (DC): 50V; Width: 0.8mm
R33 = RES 15K 0805: 125Mw Thick Film Resistors 100Ppm/ 1% 15K 0805 Chip Resistor Surface Mount Rohs; Manufacturer: Yageo; Manufacturer Part Number: RC0805FR-0715KL; Temperature Coefficient: 100ppm/°C; Value: 15kR; Voltage Rating: 150V; Case Code (Imperial): 0805; Case Code (Metric): 2012; Case/Package: 0805; Category: Chip SMD Resistors; Component Kind: Standard; ComponentLink1Description: https://datasheet.ciiva.com/46412/444164-46412861.pdf?src-supplier=Element14; ComponentLink1URL: https://datasheet.ciiva.com/46412/444164-46412861.pdf?src-supplier=Element14; ComponentLink2Description: https://datasheet.ciiva.com/46447/444164-46447567.pdf?src-supplier=Element14; ComponentLink2URL: https://datasheet.ciiva.com/46447/444164-46447567.pdf?src-supplier=Element14; ComponentLink3Description: https://datasheet.ciiva.com/2457/pyu-r-int-thick-7-2457069.pdf?src-supplier=Digikey; ComponentLink3URL: https://datasheet.ciiva.com/2457/pyu-r-int-thick-7-2457069.pdf?src-supplier=Digikey; ComponentLink4Description: https://datasheet.ciiva.com/2457/pyu-r-int-thick-7-2457070.pdf?src-supplier=Digikey; ComponentLink4URL: https://datasheet.ciiva.com/2457/pyu-r-int-thick-7-2457070.pdf?src-supplier=Digikey; ComponentLink5Description: https://datasheet.ciiva.com/2457/pyu-r-int-thick-7-2457068.pdf?src-supplier=Digikey; ComponentLink5URL: https://datasheet.ciiva.com/2457/pyu-r-int-thick-7-2457068.pdf?src-supplier=Digikey; ComponentLink6Description: https://datasheet.ciiva.com/12577/rc0805-12577708.pdf?src-supplier=Mouser; ComponentLink6URL: https://datasheet.ciiva.com/12577/rc0805-12577708.pdf?src-supplier=Mouser; Construction: Rectangular; Depth: 1.25mm; Features: Moisture Resistant; Height: 0.024inch; Lead Free: 5697LeadFree; Length: 2mm; Library Name: Schlib1.SchLib; Max Operating Temperature: 155°C; Max Power Dissipation: 125mW; Military Standard: Not; Min Operating Temperature: -55°C; Number of Terminations: 2; Package Quantity: 1; Packaging: Tape and Reel; Power Rating: 125mW; Pricing: 5000=0,00212, 10000=0,00209, 20000=0,00206, 30000=0,00203, 40000=0,00199 (USD); Reach SVHC Compliant: No; Resistance Tolerance: 1%; Resistor Type: Thick Film; RoHS: Yes; RoHS Compliant: Yes; Series: RC; Stock: 15000; Supplier: Avnet; Supplier Part Number: RC0805FR-0715KL; Termination: SMD/SMT; Tolerance: 1%; Voltage Rating (AC): 150V; Weight: 0.000194oz; Width: 0.049inch
R36 R37 = RES 5.1K 0805: Res Thin Film 0805 5.1K Ohm 0.1% 1/8W ±10ppm/°C Molded SMD Paper T/R; Manufacturer: Yageo; Manufacturer Part Number: RT0805BRD075K1L; Max Power Dissipation: 125mW; Temperature Coefficient: 25ppm/°C; Value: 5.1kR; Voltage Rating: 150V; Case Code (Imperial): 0805; Case Code (Metric): 2012; Case/Package: 0805; Category: Chip SMD Resistors; Component Kind: Standard; ComponentLink1Description: https://datasheet.ciiva.com/12810/pyu-rt-1-to-0-05-rohs-l-4-12810709.pdf?src-supplier=Mouser; ComponentLink1URL: https://datasheet.ciiva.com/12810/pyu-rt-1-to-0-05-rohs-l-4-12810709.pdf?src-supplier=Mouser; ComponentLink2Description: https://datasheet.ciiva.com/2585/pyu-rt-1-to-0-05-rohs-l-4-2585107.pdf?src-supplier=Digikey; ComponentLink2URL: https://datasheet.ciiva.com/2585/pyu-rt-1-to-0-05-rohs-l-4-2585107.pdf?src-supplier=Digikey; ComponentLink3Description: https://datasheet.ciiva.com/46635/pyu-rt-1-to-0-05-rohs-l-5-46635340.pdf?src-supplier=Digikey; ComponentLink3URL: https://datasheet.ciiva.com/46635/pyu-rt-1-to-0-05-rohs-l-5-46635340.pdf?src-supplier=Digikey; Features: Moisture Resistant; Height: 0.024inch; Lead Free: Lead Free; Length: 0.079inch; Library Name: Schlib1.SchLib; Max Operating Temperature: 155°C; Min Operating Temperature: -55°C; Number of Terminations: 2; Package Quantity: 5000; Packaging: Tape and Reel; Power Rating: 125mW; Pricing: 1=0,14, 10=0,099, 50=0,0918, 100=0,0826, 500=0,06324, 1000=0,05712 (USD); RoHS: Yes; RoHS Compliant: Yes; Series: RT; Stock: 24867; Supplier: Digikey; Supplier Part Number: YAG1938CT-ND; Termination: SMD/SMT; Voltage Rating (DC): 150V; Weight: 0.000194oz; Width: 1.25mm
U9 = EC200UEUAA-N05-SGNSA: Manufacturer: Quectel Wireless Solutions; Category: Uncategorized; Component Kind: Standard; Library Name: Schlib1.SchLib; Manufacturer Part Number: EC200UEUAA-N05-SGNSA; Pricing: 250=17,84728, 500=17,1182 (USD); RoHS: No; Supplier: Digikey; Supplier Part Number: 2958-EC200UEUAA-N05-SGNSATR-ND
